# Altium schematic sheet Sheet2.SchDoc
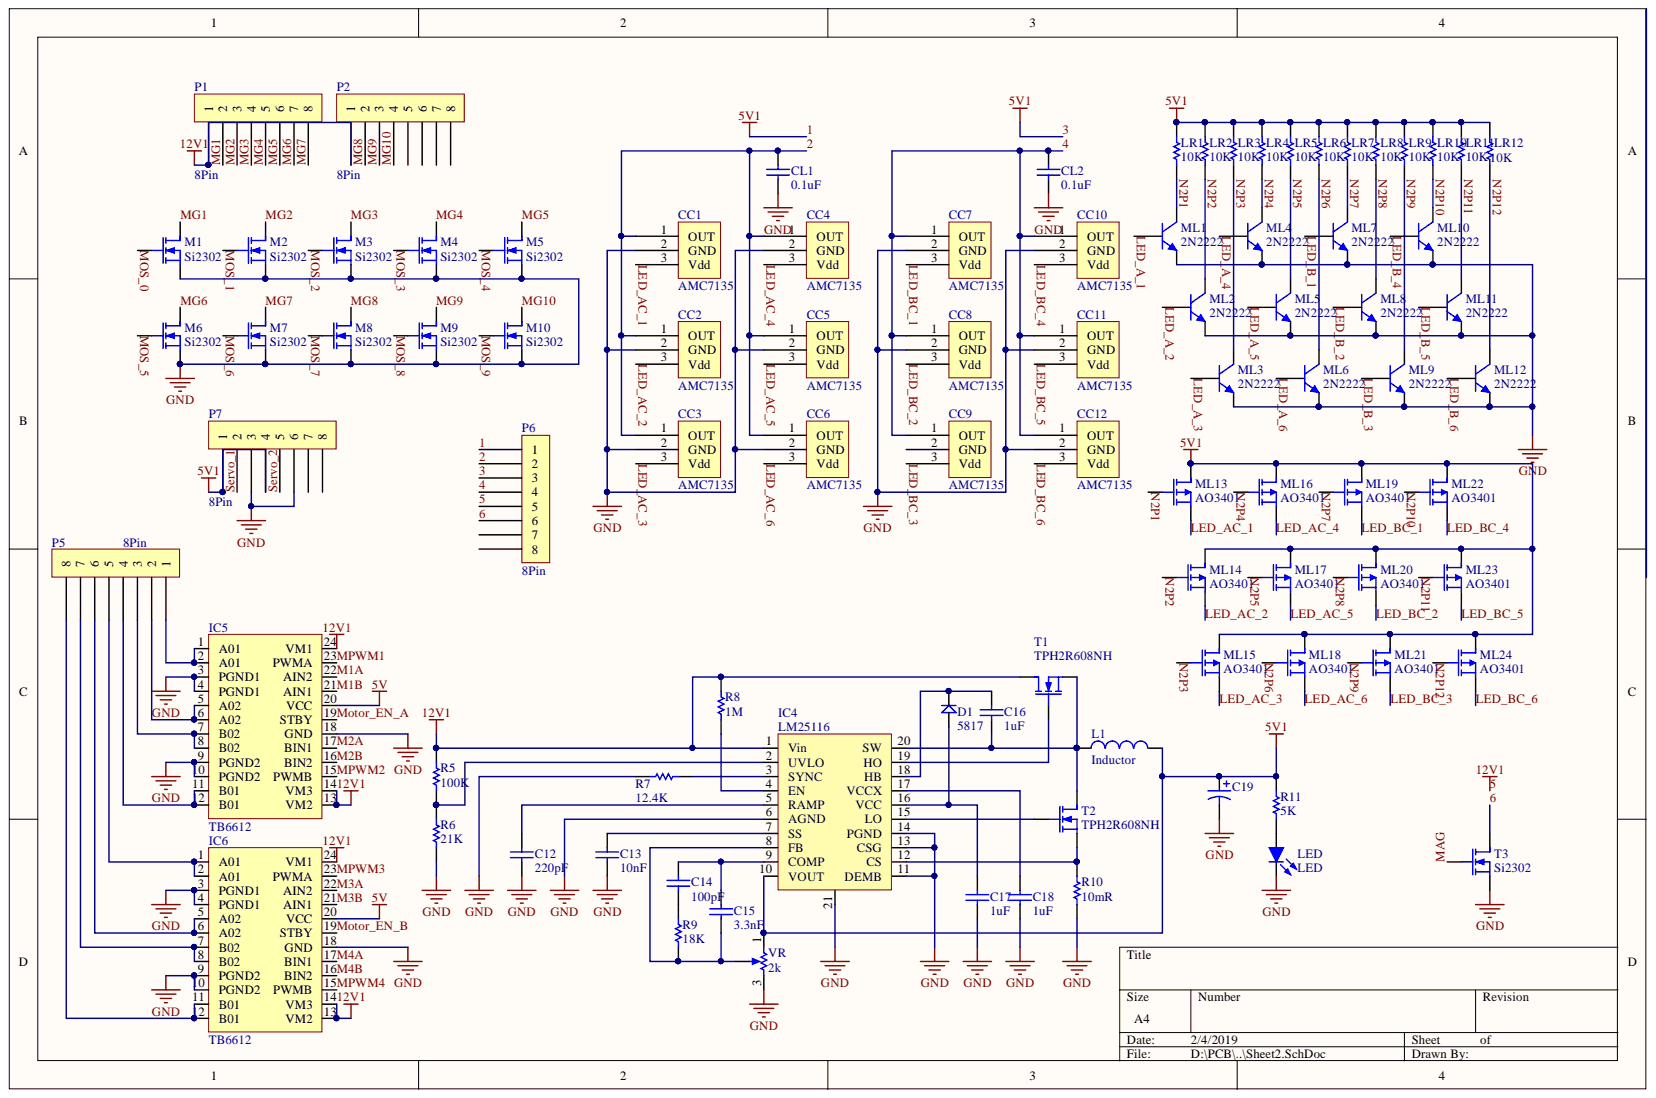
[diagram p0: Sheet2.SchDoc]

## Parts
C12  220pF  [C0805]
C13  10nF  [C0805]
C14  100pF  [C0805]
C15  3.3nF  [C0805]
C16  1uF  [C0805]
C17  1uF  [C0805]
C18  1uF  [C0805]
C19  (no value)  [Cap SMD 10x12.7mm]
CC1  AMC7135  [SOT89-150P410X160-3L]
CC2  AMC7135  [SOT89-150P410X160-3L]
CC3  AMC7135  [SOT89-150P410X160-3L]
CC4  AMC7135  [SOT89-150P410X160-3L]
CC5  AMC7135  [SOT89-150P410X160-3L]
CC6  AMC7135  [SOT89-150P410X160-3L]
CC7  AMC7135  [SOT89-150P410X160-3L]
CC8  AMC7135  [SOT89-150P410X160-3L]
CC9  AMC7135  [SOT89-150P410X160-3L]
CC10  AMC7135  [SOT89-150P410X160-3L]
CC11  AMC7135  [SOT89-150P410X160-3L]
CC12  AMC7135  [SOT89-150P410X160-3L]
CL1  0.1uF  [C0805]
CL2  0.1uF  [C0805]
D1  5817  [Diode-SOD-323]
IC4  LM25116  [HTSSOP-20]
IC5  TB6612  [SSOP24]
IC6  TB6612  [SSOP24]
L1  Inductor  [Inductor-CDEP147]
LED  LED  [LED-0805]
LR1  10K  [R0805]
LR2  10K  [R0805]
LR3  10K  [R0805]
LR4  10K  [R0805]
LR5  10K  [R0805]
LR6  10K  [R0805]
LR7  10K  [R0805]
LR8  10K  [R0805]
LR9  10K  [R0805]
LR10  10K  [R0805]
LR11  10K  [R0805]
LR12  10K  [R0805]
M1  Si2302  [SOT23]
M2  Si2302  [SOT23]
M3  Si2302  [SOT23]
M4  Si2302  [SOT23]
M5  Si2302  [SOT23]
M6  Si2302  [SOT23]
M7  Si2302  [SOT23]
M8  Si2302  [SOT23]
M9  Si2302  [SOT23]
M10  Si2302  [SOT23]
ML1  2N2222  [SOT23]
ML2  2N2222  [SOT23]
ML3  2N2222  [SOT23]
ML4  2N2222  [SOT23]
ML5  2N2222  [SOT23]
ML6  2N2222  [SOT23]
ML7  2N2222  [SOT23]
ML8  2N2222  [SOT23]
ML9  2N2222  [SOT23]
ML10  2N2222  [SOT23]
ML11  2N2222  [SOT23]
ML12  2N2222  [SOT23]
ML13  AO3401  [SOT23]
ML14  AO3401  [SOT23]
ML15  AO3401  [SOT23]
ML16  AO3401  [SOT23]
ML17  AO3401  [SOT23]
ML18  AO3401  [SOT23]
ML19  AO3401  [SOT23]
ML20  AO3401  [SOT23]
ML21  AO3401  [SOT23]
ML22  AO3401  [SOT23]
ML23  AO3401  [SOT23]
ML24  AO3401  [SOT23]
P1  8Pin  [XH2.54-8pin_SMD]
P2  8Pin  [XH2.54-8pin_SMD]
P5  8Pin  [XH2.54-8pin_SMD]
P6  8Pin  [XH2.54-8pin_SMD]
P7  8Pin  [XH2.54-8pin_SMD]
R5  100K  [R0805]
R6  21K  [R0805]
R7  12.4K  [R0805]
R8  1M  [R0805]
R9  18K  [R0805]
R10  10mR  [R2010]
R11  5K  [R0805]
T1  TPH2R608NH  [TPH2R608NH]
T2  TPH2R608NH  [TPH2R608NH]
T3  Si2302  [SOT23]
VR  2k  [3224W-1-202E]

## Nets
5V: IC5.20(VCC) IC6.20(VCC)
GND: C12.1 C13.1 C17.1 C18.1 C19.2 CC1.2 CC2.2 CC3.2 CC4.2 CC5.2 CC6.2 CC7.2 CC8.2 CC10.2 CC11.2 CC12.2 CL1.1 CL2.1 IC4.6(AGND) IC4.11(DEMB) IC4.13(CSG) IC4.14(PGND) IC4.21 IC5.3(PGND1) IC5.4(PGND1) IC5.9(PGND2) IC5.10(PGND2) IC5.18(GND) IC6.3(PGND1) IC6.4(PGND1) IC6.9(PGND2) IC6.10(PGND2) IC6.18(GND) LED.2 M1.2 M2.2 M3.2 M4.2 M5.2 M6.2 M7.2 M8.2 M9.2 M10.2 ML1.2 ML2.2 ML3.2 ML4.2 ML5.2 ML6.2 ML7.2 ML8.2 ML9.2 ML10.2 ML11.2 ML12.2 P7.3 P7.6 R6.1 R7.1 R10.1 T3.2 VR.3
1: C19.1 IC4.10(VOUT) L1.2 LR1.2 LR2.2 LR3.2 LR4.2 LR5.2 LR6.2 LR7.2 LR8.2 LR9.2 LR10.2 LR11.2 LR12.2 ML13.3 ML14.3 ML15.3 ML16.3 ML17.3 ML18.3 ML19.3 ML20.3 ML21.3 ML22.3 ML23.3 ML24.3 P6.1 P6.3 P7.1 P7.4 R11.2 VR.1
2: CC1.1 CC2.1 CC3.1 CC4.1 CC5.1 CC6.1 CL1.2 P6.2
4: CC7.1 CC8.1 CC9.1 CC10.1 CC11.1 CC12.1 CL2.2 P6.4
5: IC4.1(Vin) IC5.13(VM2) IC5.14(VM3) IC5.24(VM1) IC6.13(VM2) IC6.14(VM3) IC6.24(VM1) P1.1 P2.1 P6.5 R5.2 R8.2 T1.1
6: P6.6 T3.3
LED_A_1: ML1.1
LED_A_2: ML2.1
LED_A_3: ML3.1
LED_A_4: ML4.1
LED_A_5: ML5.1
LED_A_6: ML6.1
LED_AC_1: CC1.3 ML13.2
LED_AC_2: CC2.3 ML14.2
LED_AC_3: CC3.3 ML15.2
LED_AC_4: CC4.3 ML16.2
LED_AC_5: CC5.3 ML17.2
LED_AC_6: CC6.3 ML18.2
LED_B_1: ML7.1
LED_B_2: ML8.1
LED_B_3: ML9.1
LED_B_4: ML10.1
LED_B_5: ML11.1
LED_B_6: ML12.1
LED_BC_1: CC7.3 ML19.2
LED_BC_2: CC8.3 ML20.2
LED_BC_3: CC9.3 ML21.2
LED_BC_4: CC10.3 ML22.2
LED_BC_5: CC11.3 ML23.2
LED_BC_6: CC12.3 ML24.2
M1A: IC5.22(AIN2)
M1B: IC5.21(AIN1)
M2A: IC5.17(BIN1)
M2B: IC5.16(BIN2)
M3A: IC6.22(AIN2)
M3B: IC6.21(AIN1)
M4A: IC6.17(BIN1)
M4B: IC6.16(BIN2)
MAG: T3.1
MG1: M1.3 P1.2
MG2: M2.3 P1.3
MG3: M3.3 P1.4
MG4: M4.3 P1.5
MG5: M5.3 P1.6
MG6: M6.3 P1.7
MG7: M7.3 P1.8
MG8: M8.3 P2.2
MG9: M9.3 P2.3
MG10: M10.3 P2.4
MOS_0: M1.1
MOS_1: M2.1
MOS_2: M3.1
MOS_3: M4.1
MOS_4: M5.1
MOS_5: M6.1
MOS_6: M7.1
MOS_7: M8.1
MOS_8: M9.1
MOS_9: M10.1
Motor_EN_A: IC5.19(STBY)
Motor_EN_B: IC6.19(STBY)
MPWM1: IC5.23(PWMA)
MPWM2: IC5.15(PWMB)
MPWM3: IC6.23(PWMA)
MPWM4: IC6.15(PWMB)
N2P1: LR1.1 ML1.3 ML13.1
N2P2: LR2.1 ML2.3 ML14.1
N2P3: LR3.1 ML3.3 ML15.1
N2P4: LR4.1 ML4.3 ML16.1
N2P5: LR5.1 ML5.3 ML17.1
N2P6: LR6.1 ML6.3 ML18.1
N2P7: LR7.1 ML7.3 ML19.1
N2P8: LR8.1 ML8.3 ML20.1
N2P9: LR9.1 ML9.3 ML21.1
N2P10: LR10.1 ML10.3 ML22.1
N2P11: LR11.1 ML11.3 ML23.1
N2P12: LR12.1 ML12.3 ML24.1
Servo_1: P7.2
Servo_2: P7.5
NetC12_2: C12.2 IC4.5(RAMP)
NetC13_2: C13.2 IC4.7(SS)
NetC14_1: C14.1 R9.2
NetC14_2: C14.2 C15.2 IC4.9(COMP)
NetC15_1: C15.1 IC4.8(FB) R9.1 VR.2
NetC16_1: C16.1 IC4.20(SW) L1.1 T1.3 T2.1
NetC16_2: C16.2 D1.2 IC4.18(HB)
NetC17_2: C17.2 D1.1 IC4.16(VCC)
NetC18_2: C18.2 IC4.17(VCCX)
NetIC4_2: IC4.2(UVLO) R5.1 R6.2
NetIC4_3: IC4.3(SYNC) R7.2
NetIC4_4: IC4.4(EN) R8.1
NetIC4_12: IC4.12(CS) R10.2 T2.3
NetIC4_15: IC4.15(LO) T2.2
NetIC4_19: IC4.19(HO) T1.2
NetIC5_1: IC5.1(A01) IC5.2(A01) P5.1
NetIC5_5: IC5.5(A02) IC5.6(A02) P5.2
NetIC5_7: IC5.7(B02) IC5.8(B02) P5.3
NetIC5_11: IC5.11(B01) IC5.12(B01) P5.4
NetIC6_1: IC6.1(A01) IC6.2(A01) P5.5
NetIC6_5: IC6.5(A02) IC6.6(A02) P5.6
NetIC6_7: IC6.7(B02) IC6.8(B02) P5.7
NetIC6_11: IC6.11(B01) IC6.12(B01) P5.8
NetLED_1: LED.1 R11.1
N00177:

Not connected: CC9 2; P2 5 6 7 8; P6 7 8; P7 7 8

## Library data of the parts
C12-C18 CL1 CL2 = Cap: Component Kind: Standard
  C12-C18: Library Name: Schlib1.SchLib
  CL1 CL2: Library Name: MyOwnSchlib_ver1.2.SchLib
C19 = Cap-pol: Component Kind: Standard; Library Name: Schlib1.SchLib
D1 = Diode: Component Kind: Standard; Library Name: Schlib1.SchLib
IC4 = LM25116: Buck Controller; Component Kind: Standard; Library Name: Schlib1.SchLib
LR1-LR12 R5-R11 = Resistor: Component Kind: Standard
  R5-R9 R11: Library Name: Schlib1.SchLib
  R10: Library Name: Schlib1.SchLib; value: 10mR
  LR1-LR12: Library Name: MyOwnSchlib_ver1.2.SchLib
ML1-ML12 = 2N2222: NPN; Component Kind: Standard; Library Name: MyOwnSchlib_ver1.2.SchLib
T1 T2 = MOSFET-N: Component Kind: Standard; Library Name: Schlib1.SchLib
VR = Potentiometer: Component Kind: Standard; Library Name: Schlib1.SchLib
CC1-CC12 = AMC7135: Component Kind: Standard; Library Name: MyOwnSchlib_ver1.2.SchLib
IC5 IC6 = TB6612: Component Kind: Standard; Library Name: MyOwnSchlib_ver1.2.SchLib
L1 = Inductor: Component Kind: Standard; Library Name: Schlib1.SchLib
LED = LED: Component Kind: Standard; Library Name: Schlib1.SchLib
M1-M10 T3 = Si2302: Component Kind: Standard; Library Name: MyOwnSchlib_ver1.2.SchLib
ML13-ML24 = AO3401: Component Kind: Standard; Library Name: MyOwnSchlib_ver1.2.SchLib
P1 P2 P5-P7 = 8Pin: Component Kind: Standard; Library Name: MyOwnSchlib_ver1.2.SchLib
